# Altium schematic sheet 2_LDO.SchDoc
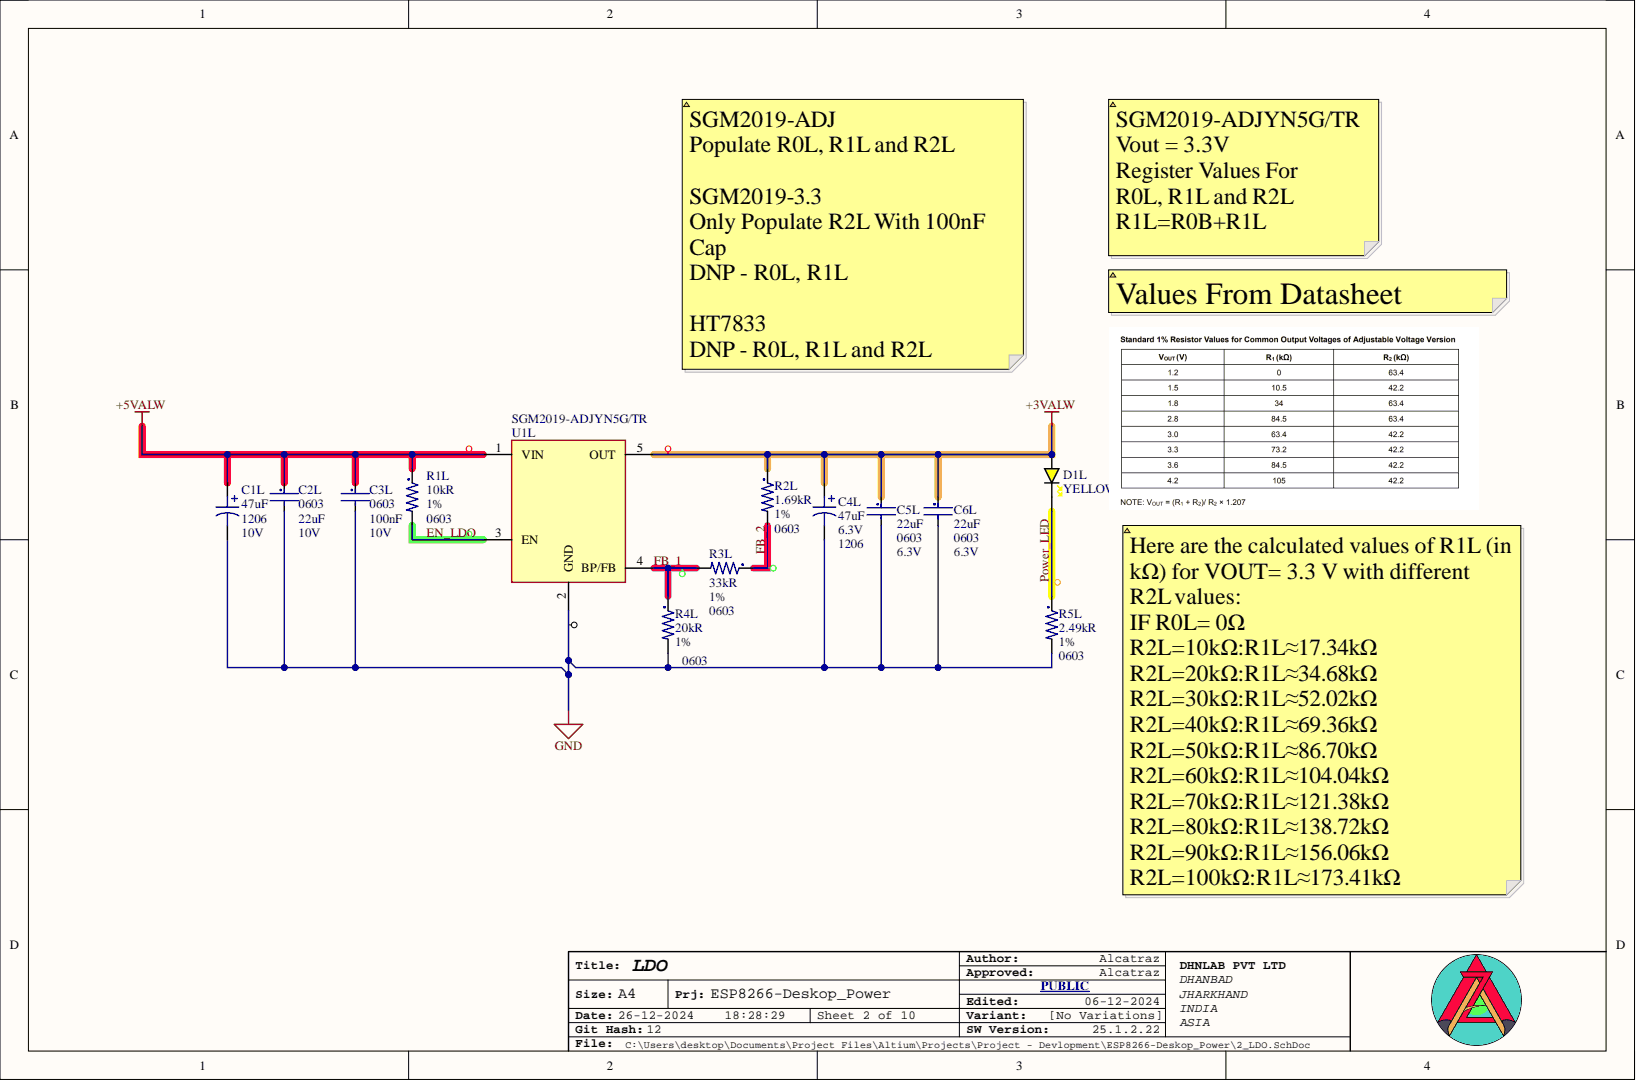
[diagram p0: 2_LDO.SchDoc]

## Parts
C1L  value 47uF  Case Code (Imperial): 1206  Voltage Rating: 10V  [CAP POL 1206_3216-MFG]  (hidden comment: TCTAL1A476M8R)
C2L  value 22uF  Case Code (Imperial): 0603  Voltage Rating: 10V  [C0603-IPC_C_No_Silk]  (hidden comment: GRM188R61A226ME15D)
C3L  value 100nF  Case Code (Imperial): 0603  Voltage: 10V  [C0603-IPC_C_No_Silk]  (hidden comment: C0603C104K8RACTU)
C4L  value 47uF  Size: 1206  Voltage: 6.3V  [CAP POL 1206_3216-MFG]  (hidden comment: T491A476M006AT)
C5L  value 22uF  Case Code (Imperial): 0603  Voltage Rating: 6.3V  [C0603-IPC_C_No_Silk]  (hidden comment: GRM188R60J226MEA0D)
C6L  value 22uF  Case Code (Imperial): 0603  Voltage Rating: 6.3V  [C0603-IPC_C_No_Silk]  (hidden comment: GRM188R60J226MEA0D)
D1L  YELLOW  [LED_0603_YELLOW]
R1L  value 10kR  Case Code (Imperial): 0603  Resistance Tolerance: 1%  [R0603-MFG]  (hidden comment: RC0603FR-0710KL)
R2L  value 1.69kR  Case Code (Imperial): 0603  Tolerance: 1%  [R0603-MFG]  (hidden comment: MCR03EZPFX1691)
R3L  value 33kR  Resistance Tolerance: 1%  [R0603-MFG]  (hidden comment: ERJ-3EKF3302V)
R4L  value 20kR  Case Code (Imperial): 0603  Resistance Tolerance: 1%  [R0603-MFG]  (hidden comment: CR0603-FX-2002ELF)
R5L  value 2.49kR  Case Code (Imperial): 0603  Tolerance: 1%  [R0603-MFG]  (hidden comment: MCR03EZPFX2491)
U1L  SGM2019-ADJYN5G/TR  [TSOT-23-5-IPC-A]

## Nets
+3VALW: C4L.1 C5L.1 C6L.1 D1L.2 R2L.1 U1L.5(OUT)
+5VALW: C1L.1 C2L.1 C3L.1 R1L.1 U1L.1(VIN)
GND: C1L.2 C2L.2 C3L.2 C4L.2 C5L.2 C6L.2 R4L.2 R5L.2 U1L.2(GND)
EN_LDO: R1L.2 U1L.3(EN)
FB_1: R3L.2 R4L.1 U1L.4(BP/FB)
FB_2: R2L.2 R3L.1
Power_LED: D1L.1 R5L.1

## Library data of the parts
C1L = 47uF_10V: Surface Mount Tantalum Capacitor, 47 uF, 10 V, ± 20%, -55 °C, 125 °C, 1206 [3216-12 Metric]; Manufacturer: Rohm; Case Code (Metric): 3216; Case/Package: 1206; Category: Tantalum Capacitors; Component Kind: Standard; ComponentLink1Description: https://datasheet.ciiva.com/258/tct-al-258880.pdf?src-supplier=Digikey; ComponentLink1URL: https://datasheet.ciiva.com/258/tct-al-258880.pdf?src-supplier=Digikey; ComponentLink2Description: https://datasheet.ciiva.com/258/tct-al-258881.pdf?src-supplier=Digikey; ComponentLink2URL: https://datasheet.ciiva.com/258/tct-al-258881.pdf?src-supplier=Digikey; ComponentLink3Description: https://datasheet.ciiva.com/258/tct-al-258879.pdf?src-supplier=Digikey; ComponentLink3URL: https://datasheet.ciiva.com/258/tct-al-258879.pdf?src-supplier=Digikey; ComponentLink4Description: https://datasheet.ciiva.com/16394/tct-al-16394319.pdf?src-supplier=Future+Electronics; ComponentLink4URL: https://datasheet.ciiva.com/16394/tct-al-16394319.pdf?src-supplier=Future+Electronics; Construction: Flat; Depth: 1.6mm; Features: General Purpose; Height: 1.1mm; Lead Free: Lead Free; Length: 3.2mm; Manufacturer Part Number: TCTAL1A476M8R; Max Operating Temperature: 125°C; Min Operating Temperature: -55°C; Mounting Technology: Surface Mount; Packaging: Cut Tape; Pricing 1: 1=0.82, 10=0.622 (USD); Radiation Hardening: No; RoHS: Yes; RoHS Compliant: Yes; Series: TCT; Stock 1: 250; Supplier 1: Ameya360; Supplier Part Number 1: TCTAL1A476M8R; Tolerance: 20%; Voltage Rating (DC): 10V; Library Name: Lib_Capacitor_1206_Polarised.IntLib
C2L = 22uF_10V: Multilayer Ceramic Capacitor, 22 uF, 10 V, ± 20%, X5R, 0603 [1608 Metric]; Manufacturer: Murata; Case Code (Metric): 1608; Case/Package: 0603; Category: Ceramic Capacitors; Component Kind: Standard; ComponentLink1Description: https://datasheet.ciiva.com/46444/1769893-46444975.pdf?src-supplier=Element14; ComponentLink1URL: https://datasheet.ciiva.com/46444/1769893-46444975.pdf?src-supplier=Element14; Dielectric: X5R; Height: 0.8mm; Lead Free: 5697LeadFree; Length: 1.6mm; Library Name: Lib_Capacitor_0603.IntLib; Manufacturer Part Number: GRM188R61A226ME15D; Material: Ceramic; Max Operating Temperature: 85°C; Min Operating Temperature: -55°C; Mounting Technology: Surface Mount; Package Quantity: 4000; Packaging: Cut Tape; Pricing 1: 1=0.2, 10=0.088, 50=0.088, 100=0.051, 1000=0.041, 10000=0.037 (USD); Reach SVHC Compliant: No; RoHS: Yes; RoHS Compliant: Yes; Series: GRM; Stock 1: 596743; Supplier 1: Mouser; Supplier Part Number 1: 81-GRM188R61A226ME5D; Termination: SMD/SMT; Thickness: 0.039inch; Tolerance: 20%; Voltage Rating (DC): 10V; Weight: 0.000222oz; Width: 0.8mm
C3L = 100nF_10V: Multilayer Ceramic Capacitor, 0.1 uF, 10 V, ± 10%, X7R, 0603 [1608 Metric]; Manufacturer: KEMET; Dissipation Factor: 5%; Material: 4241ConductorMaterial; Temperature Coefficient: 30ppm/°C; Case Code (Metric): 1608; Case/Package: 0603; Category: Ceramic Capacitors; Component Kind: Standard; ComponentLink1Description: https://datasheet.ciiva.com/6093/1776114-6093269.pdf?src-supplier=Farnell; ComponentLink1URL: https://datasheet.ciiva.com/6093/1776114-6093269.pdf?src-supplier=Farnell; ComponentLink2Description: https://datasheet.ciiva.com/7823/kem-c1002-x7r-smd-7823989.pdf?src-supplier=Element14; ComponentLink2URL: https://datasheet.ciiva.com/7823/kem-c1002-x7r-smd-7823989.pdf?src-supplier=Element14; ComponentLink3Description: https://datasheet.ciiva.com/22693/1851736-22693967.pdf?src-supplier=Element14; ComponentLink3URL: https://datasheet.ciiva.com/22693/1851736-22693967.pdf?src-supplier=Element14; ComponentLink4Description: https://datasheet.ciiva.com/14589/70095383-14589402.pdf?src-supplier=Allied+Electronics; ComponentLink4URL: https://datasheet.ciiva.com/14589/70095383-14589402.pdf?src-supplier=Allied+Electronics; ComponentLink5Description: https://datasheet.ciiva.com/14593/70095383-14593978.pdf?src-supplier=Allied+Electronics; ComponentLink5URL: https://datasheet.ciiva.com/14593/70095383-14593978.pdf?src-supplier=Allied+Electronics; ComponentLink6Description: https://datasheet.ciiva.com/5419/kem-c1002-x7r-smd-357932-5419657.pdf?src-supplier=Mouser; ComponentLink6URL: https://datasheet.ciiva.com/5419/kem-c1002-x7r-smd-357932-5419657.pdf?src-supplier=Mouser; ComponentLink7Description: https://datasheet.ciiva.com/18192/getdatasheetpartid-91529-18192711.pdf?src-supplier=Verical; ComponentLink7URL: https://datasheet.ciiva.com/18192/getdatasheetpartid-91529-18192711.pdf?src-supplier=Verical; Construction: Flat; Depth: 0.8mm; Dielectric: X7R; Dielectric Material: Ceramic; Height: 0.8mm; Lead Free: 5697LeadFree; Lead Pitch: 0.7mm; Length: 1.6mm; Library Name: Lib_Capacitor_0603.IntLib; Manufacturer Part Number: C0603C104K8RACTU; Max Operating Temperature: 125°C; Min Operating Temperature: -55°C; Mounting Technology: Surface Mount,PCB; Package Quantity: 1; Packaging: Tape and Reel; Pins: 2; Pricing 1: 1=0.11, 10=0.075, 50=0.075, 100=0.036, 1000=0.021, 10000=0.017 (USD); Radiation Hardening: No; Reach SVHC Compliant: No; RoHS: Yes; RoHS Compliant: Yes; Series: C; Stock 1: 246409; Supplier 1: Mouser; Supplier Part Number 1: 80-C0603C104K8R; Termination: SMD/SMT; Thickness: 0.034inch; Tolerance: 10%; Voltage Rating: 10V; Voltage Rating (DC): 10V; Width: 0.031inch
C4L = 47uF_6.3V: 47uF Solid MnO2 Tantalum Electrolytic Capacitor; 6.3 V dc +/-20%; T491 Series; Manufacturer: KEMET; Category: Tantalum Capacitors; Component Kind: Standard; ComponentLink1Description: https://datasheet.ciiva.com/18096/getdatasheetpartid-132583-18096186.pdf?src-supplier=Verical; ComponentLink1URL: https://datasheet.ciiva.com/18096/getdatasheetpartid-132583-18096186.pdf?src-supplier=Verical; ComponentLink2Description: https://datasheet.ciiva.com/258/kem-t2005-t491-258163.pdf?src-supplier=Digikey; ComponentLink2URL: https://datasheet.ciiva.com/258/kem-t2005-t491-258163.pdf?src-supplier=Digikey; ComponentLink3Description: https://datasheet.ciiva.com/258/kem-t2005-t491-258164.pdf?src-supplier=Digikey; ComponentLink3URL: https://datasheet.ciiva.com/258/kem-t2005-t491-258164.pdf?src-supplier=Digikey; ComponentLink4Description: https://datasheet.ciiva.com/258/kem-t2005-t491-258165.pdf?src-supplier=Digikey; ComponentLink4URL: https://datasheet.ciiva.com/258/kem-t2005-t491-258165.pdf?src-supplier=Digikey; ComponentLink5Description: https://datasheet.ciiva.com/12883/kem-t2005-t491-12883464.pdf?src-supplier=Mouser; ComponentLink5URL: https://datasheet.ciiva.com/12883/kem-t2005-t491-12883464.pdf?src-supplier=Mouser; ComponentLink6Description: https://datasheet.ciiva.com/14103/t491serie-eng-tds-14103966.pdf?src-supplier=Distrelec; ComponentLink6URL: https://datasheet.ciiva.com/14103/t491serie-eng-tds-14103966.pdf?src-supplier=Distrelec; ComponentLink7Description: https://datasheet.ciiva.com/28561/kem-t2005-t491-28561180.pdf?src-supplier=Element14; ComponentLink7URL: https://datasheet.ciiva.com/28561/kem-t2005-t491-28561180.pdf?src-supplier=Element14; ComponentLink8Description: https://datasheet.ciiva.com/7851/1854511-7851173.pdf?src-supplier=Element14; ComponentLink8URL: https://datasheet.ciiva.com/7851/1854511-7851173.pdf?src-supplier=Element14; ComponentLink9Description: https://datasheet.ciiva.com/7851/1854511-7851174.pdf?src-supplier=Element14; ComponentLink9URL: https://datasheet.ciiva.com/7851/1854511-7851174.pdf?src-supplier=Element14; Manufacturer Part Number: T491A476M006AT; Pricing 1: 1=0.58, 10=0.394, 50=0.394, 100=0.259, 1000=0.172, 10000=0.139 (USD); RoHS: Yes; Stock 1: 52790; Supplier 1: Mouser; Supplier Part Number 1: 80-T491A476M06; Library Name: Lib_Capacitor_1206_Polarised.IntLib
C5L C6L = 22uF_6.3V: Multilayer Ceramic Capacitor, 22 uF, 6.3 V, ± 20%, X5R, 0603 [1608 Metric]; Manufacturer: Murata; Material: 4241ConductorMaterial; Case Code (Metric): 1608; Case/Package: 0603; Category: Ceramic Capacitors; Component Kind: Standard; ComponentLink1Description: https://datasheet.ciiva.com/29540/partnumbering-e-01-29540442.pdf?src-supplier=Digikey; ComponentLink1URL: https://datasheet.ciiva.com/29540/partnumbering-e-01-29540442.pdf?src-supplier=Digikey; ComponentLink2Description: https://datasheet.ciiva.com/29540/partnumbering-e-01-29540441.pdf?src-supplier=Digikey; ComponentLink2URL: https://datasheet.ciiva.com/29540/partnumbering-e-01-29540441.pdf?src-supplier=Digikey; ComponentLink3Description: https://datasheet.ciiva.com/29540/partnumbering-e-01-29540443.pdf?src-supplier=Digikey; ComponentLink3URL: https://datasheet.ciiva.com/29540/partnumbering-e-01-29540443.pdf?src-supplier=Digikey; Dielectric: X5R; Height: 0.8mm; Lead Free: 5697LeadFree; Length: 0.063inch; Library Name: Lib_Capacitor_0603.IntLib; Manufacturer Part Number: GRM188R60J226MEA0D; Max Operating Temperature: 85°C; Min Operating Temperature: -55°C; Mounting Technology: Surface Mount; Package Quantity: 1; Packaging: Tape and Reel; Pins: 2NUMBER; Pricing 1: 1=0.2, 10=0.078, 50=0.078, 100=0.045, 1000=0.04, 10000=0.033 (USD); Reach SVHC Compliant: No; RoHS: Yes; RoHS Compliant: Yes; Series: GRM; Stock 1: 856547; Supplier 1: Mouser; Supplier Part Number 1: 81-GRM188R60J226ME0D; Termination: SMD/SMT; Thickness: 0.039inch; Tolerance: 20%; Voltage Rating (DC): 6.3V; Weight: 0.000222oz; Width: 0.031inch
D1L = LED_0603_YELLOW: LED 0603 YELLOW SMD; Component Kind: Standard; Library Name: Lib_LED.IntLib
R1L = 10K: SMD Chip Resistor, 10 kOhm, ± 1%, 100 mW, 0603 [1608 Metric], Thick Film, General Purpose; Manufacturer: Yageo; Max Power Dissipation: 100mW; Temperature Coefficient: 100ppm/°C; Voltage Rating: 75V; Voltage Rating (DC): 50V; Case Code (Metric): 1608; Case/Package: 0603; Category: Chip SMD Resistors; Component Kind: Standard; Construction: Rectangular; Depth: 0.8mm; Features: Moisture Resistant; Height: 0.45mm; Lead Free: 5697LeadFree; Lead Pitch: 0.3mm; Length: 1.6mm; Library Name: Lib_Register_0603.IntLib; Manufacturer Part Number: RC0603FR-0710KL; Max Operating Temperature: 155°C; Military Standard: Not; Min Operating Temperature: -55°C; Number of Terminations: 2; Packaging: Tape and Reel; Pins: 2; Power Rating: 100mW; Pricing 1: 5000=0.0015, 50000=0.0014, 150000=0.0014, 375000=0.0013, 1000000=0.0012 (USD); Reach SVHC Compliant: No; Resistor Type: Thick Film; RoHS: Yes; RoHS Compliant: Yes; Series: RC; Stock 1: 44660000; Supplier 1: Future Electronics; Supplier Part Number 1: 4023214; Termination: SMD/SMT; Tolerance: 1%; Weight: 7.1E-05oz; Width: 0.8mm
R2L = 1.69K: Surface Mount Thick Film Chip Resistor 0603 Case 1.69K Ohms 1% Tolerance 100 PPM; Manufacturer: Rohm; Max Power Dissipation: 100mW; Temperature Coefficient: 100ppm/°C; Voltage Rating (DC): 50V; Case Code (Metric): 1608; Case/Package: 0603; Category: Chip SMD Resistors; Component Kind: Standard; ComponentLink1Description: http://rohmfs.rohm.com/en/products/databook/datasheet/passive/resistor/chip_resistor/mcr.pdf?src-supplier=Avnet; ComponentLink1URL: http://rohmfs.rohm.com/en/products/databook/datasheet/passive/resistor/chip_resistor/mcr.pdf?src-supplier=Avnet; ComponentLink2Description: http://www.rohm.com/web/global/datasheet/MCR03EZPFX/mcr-e?src-supplier=Digikey; ComponentLink2URL: http://www.rohm.com/web/global/datasheet/MCR03EZPFX/mcr-e?src-supplier=Digikey; Features: Automotive AEC-Q200; Height: 0.022inch; Lead Free: Lead Free; Length: 0.063inch; Library Name: Lib_Register_0603.IntLib; Manufacturer Part Number: MCR03EZPFX1691; Max Operating Temperature: 155°C; Min Operating Temperature: -55°C; Mounting Technology: Surface Mount; Number of Terminations: 2; Package Quantity: 1; Packaging: Cut Tape; Power Rating: 100mW; Pricing 1: 1=0.0232, 217=0.0145, 1380=0.0087, 5748=0.0044 (USD); RoHS: Yes; RoHS Compliant: Yes; Series: MCR03; Stock 1: 11347; Supplier 1: Quest; Supplier Part Number 1: MCR03EZPFX1691; Width: 0.031inch
R3L = 33K: Res Thick Film 0603 33K Ohm 1% 0.1W(1/10W) ±100ppm/C Pad SMD Automotive T/R; Manufacturer: Panasonic; Max Power Dissipation: 100mW; Temperature Coefficient: 100ppm/°C; Voltage Rating: 75V; Case Code (Imperial): 0603; Case Code (Metric): 1608; Case/Package: 0603; Category: Chip SMD Resistors; Component Kind: Standard; Construction: Rectangular; Contact Plating: Tin; Depth: 0.8mm; Features: Automotive AEC-Q200; Height: 0.022inch; Lead Free: 5697LeadFree; Length: 1.6mm; Library Name: Lib_Register_0603.IntLib; Manufacturer Part Number: ERJ-3EKF3302V; Max Operating Temperature: 155°C; Military Standard: Not; Min Operating Temperature: -55°C; Mounting Technology: Surface Mount; Number of Terminations: 2; Package Quantity: 1; Packaging: Tape and Reel; Pins: 2; Power Rating: 100mW; Pricing 1: 5000=0.0041, 10000=0.0039, 20000=0.0037, 40000=0.0036, 50000=0.0035 (USD); Radiation Hardening: No; Reach SVHC Compliant: No; Resistor Type: Thick Film; RoHS: Yes; RoHS Compliant: Yes; Series: ERJ; Stock 1: 350000; Supplier 1: Onlinecomponents.com; Supplier Part Number 1: ERJ3EKF3302V; Termination: SMD/SMT; Tolerance: 1%; Voltage Rating (DC): 75V; Width: 0.8mm
R4L = 20K: SMD Chip Resistor, 20 kOhm, ± 1%, 100 mW, 0603 [1608 Metric], Thick Film, General Purpose; Manufacturer: Bourns; Max Power Dissipation: 100mW; Temperature Coefficient: 100ppm/°C; Voltage Rating: 50V; Case Code (Metric): 1608; Case/Package: 0603; Category: Chip SMD Resistors; Component Kind: Standard; ComponentLink1Description: https://datasheet.ciiva.com/11809/0900766b80fcdb72-11809666.pdf?src-supplier=Rs; ComponentLink1URL: https://datasheet.ciiva.com/11809/0900766b80fcdb72-11809666.pdf?src-supplier=Rs; ComponentLink2Description: https://datasheet.ciiva.com/9121/chpreztr-9121928.pdf?src-supplier=Avnet; ComponentLink2URL: https://datasheet.ciiva.com/9121/chpreztr-9121928.pdf?src-supplier=Avnet; Contact Plating: Tin; Depth: 0.8mm; Features: Moisture Resistant; Height: 0.45mm; Length: 1.6mm; Library Name: Lib_Register_0603.IntLib; Manufacturer Part Number: CR0603-FX-2002ELF; Max Operating Temperature: 155°C; Min Operating Temperature: -55°C; Mounting Technology: Surface Mount; Number of Terminations: 2; Package Quantity: 1; Packaging: Tape and Reel; Power Rating: 100mW; Pricing 1: 20000=0.0014, 40000=0.0013, 80000=0.0013, 160000=0.0012 (USD); Radiation Hardening: No; Reach SVHC Compliant: No; Resistor Type: Thick Film; RoHS: Yes; RoHS Compliant: Yes; Series: CR0603; Stock 1: 20000; Supplier 1: Onlinecomponents.com; Supplier Part Number 1: CR0603-FX-2002ELF; Technology: THICK FILM; Termination: SMD/SMT; Thickness: 457um; Tolerance: 1%; Voltage Rating (DC): 50V; Width: 0.8mm
R5L = 2.49K: Res Thick Film 0603 2.49K Ohm 1% 1/10W ±100ppm/°C Molded SMD SMD Paper T/R; Manufacturer: Rohm; Max Power Dissipation: 100mW; Voltage Rating (DC): 50V; Case Code (Metric): 1608; Case/Package: 0603; Category: Chip SMD Resistors; Component Kind: Standard; ComponentLink1Description: https://datasheet.ciiva.com/2520/mcr-2520347.pdf?src-supplier=Digikey; ComponentLink1URL: https://datasheet.ciiva.com/2520/mcr-2520347.pdf?src-supplier=Digikey; ComponentLink2Description: https://datasheet.ciiva.com/2520/mcr-2520348.pdf?src-supplier=Digikey; ComponentLink2URL: https://datasheet.ciiva.com/2520/mcr-2520348.pdf?src-supplier=Digikey; ComponentLink3Description: https://datasheet.ciiva.com/2520/mcr-2520349.pdf?src-supplier=Digikey; ComponentLink3URL: https://datasheet.ciiva.com/2520/mcr-2520349.pdf?src-supplier=Digikey; ComponentLink4Description: https://datasheet.ciiva.com/16203/mcr03ezpd-16203167.pdf?src-supplier=Future+Electronics; ComponentLink4URL: https://datasheet.ciiva.com/16203/mcr03ezpd-16203167.pdf?src-supplier=Future+Electronics; Contact Plating: Tin; Features: Automotive AEC-Q200; Height: 0.022inch; Lead Free: Lead Free; Length: 0.063inch; Library Name: Lib_Register_0603.IntLib; Manufacturer Part Number: MCR03EZPFX2491; Max Operating Temperature: 155°C; Min Operating Temperature: -55°C; Mounting Technology: Surface Mount; Number of Terminations: 2; Package Quantity: 1; Packaging: Cut Tape; Power Rating: 100mW; Pricing 1: 1=0.036, 10=0.031, 100=0.031, 1000=0.028 (USD); RoHS: Yes; RoHS Compliant: Yes; Series: MCR03; Stock 1: 683; Supplier 1: One Stop Electro; Supplier Part Number 1: 53268691; Temperature Coefficient: 100ppm/°C; Width: 0.031inch
U1L = SGM2019-ADJYN5G/TR: LDO U-Reg Adj 0, 3A SOT23-5; Manufacturer: SG Micro; Category: LDO; Component Kind: Standard; Library Name: Lib_LDO.IntLib; Manufacturer Part Number: SGM2019-ADJYN5G/TR; RoHS: No; Stock 1: 6915; Supplier 1: Sourcengine; Supplier Part Number 1: SGM2019-ADJYN5GTR-112944978
